# Altium schematic sheet FLTR.SchDoc(FLTR)
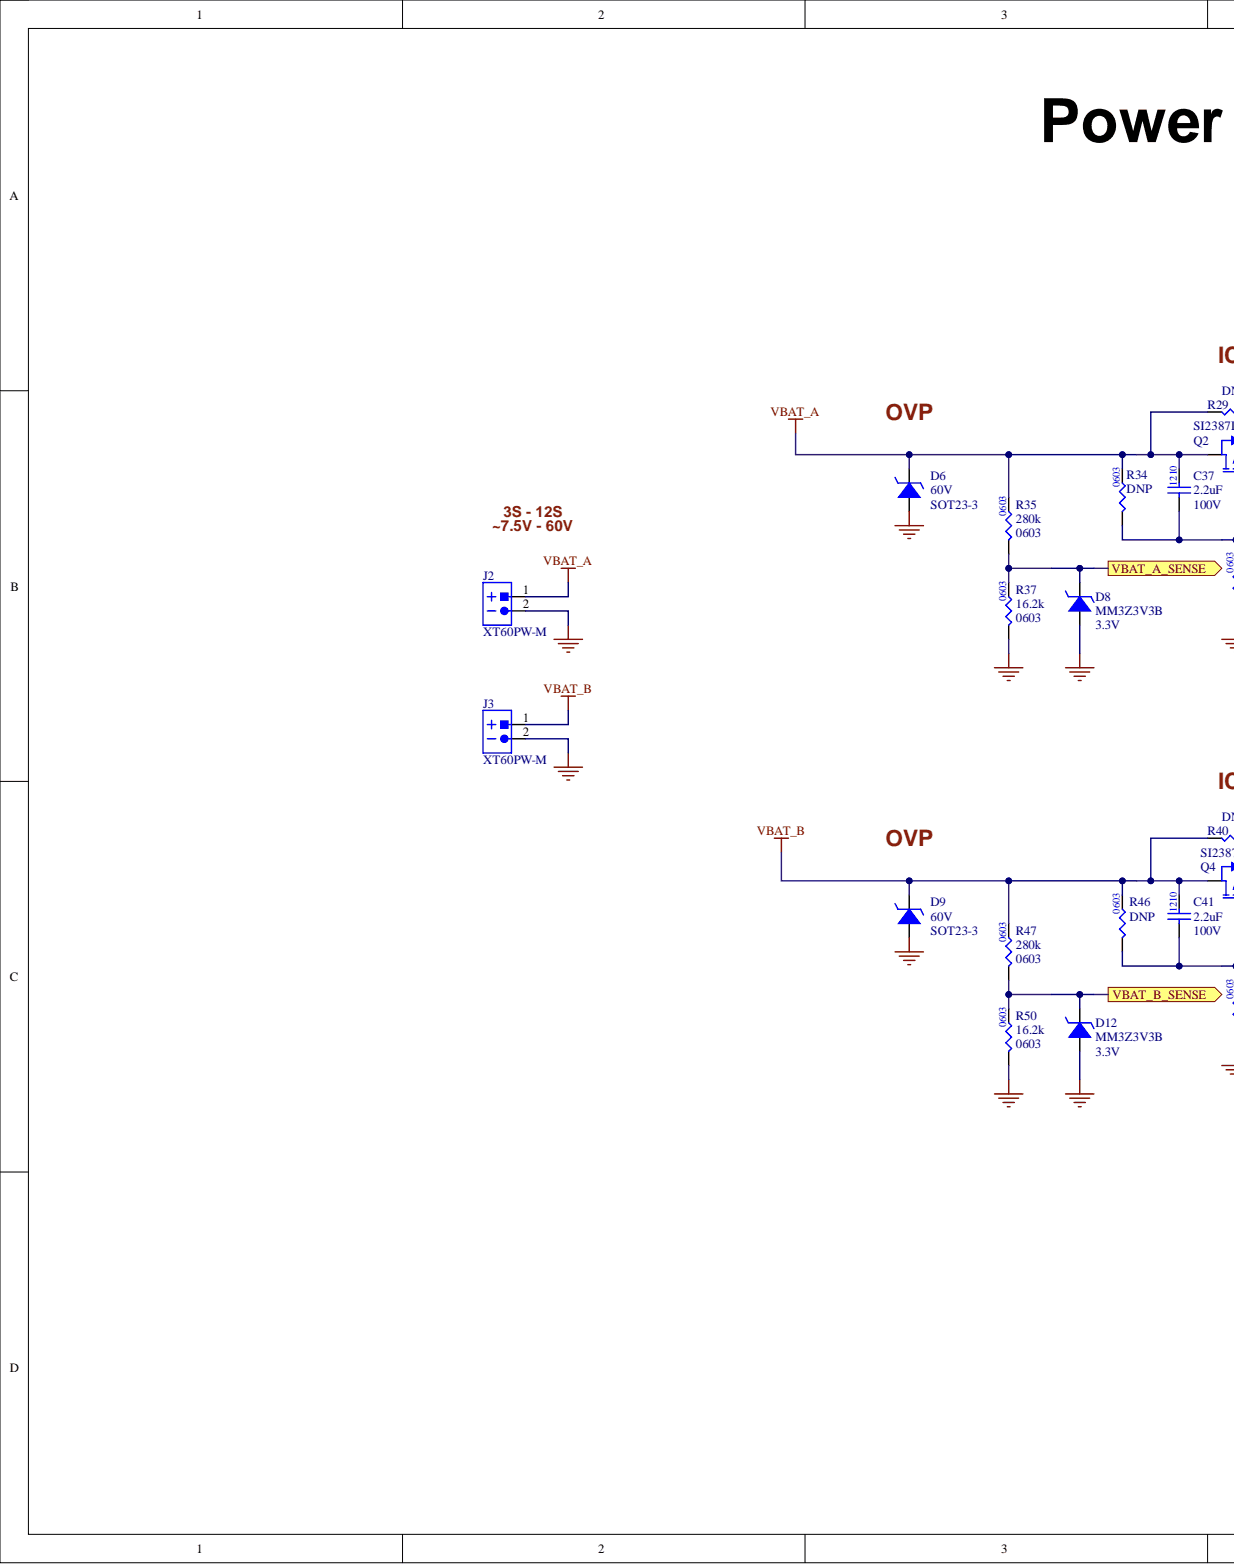
[diagram p0: FLTR.SchDoc, left]
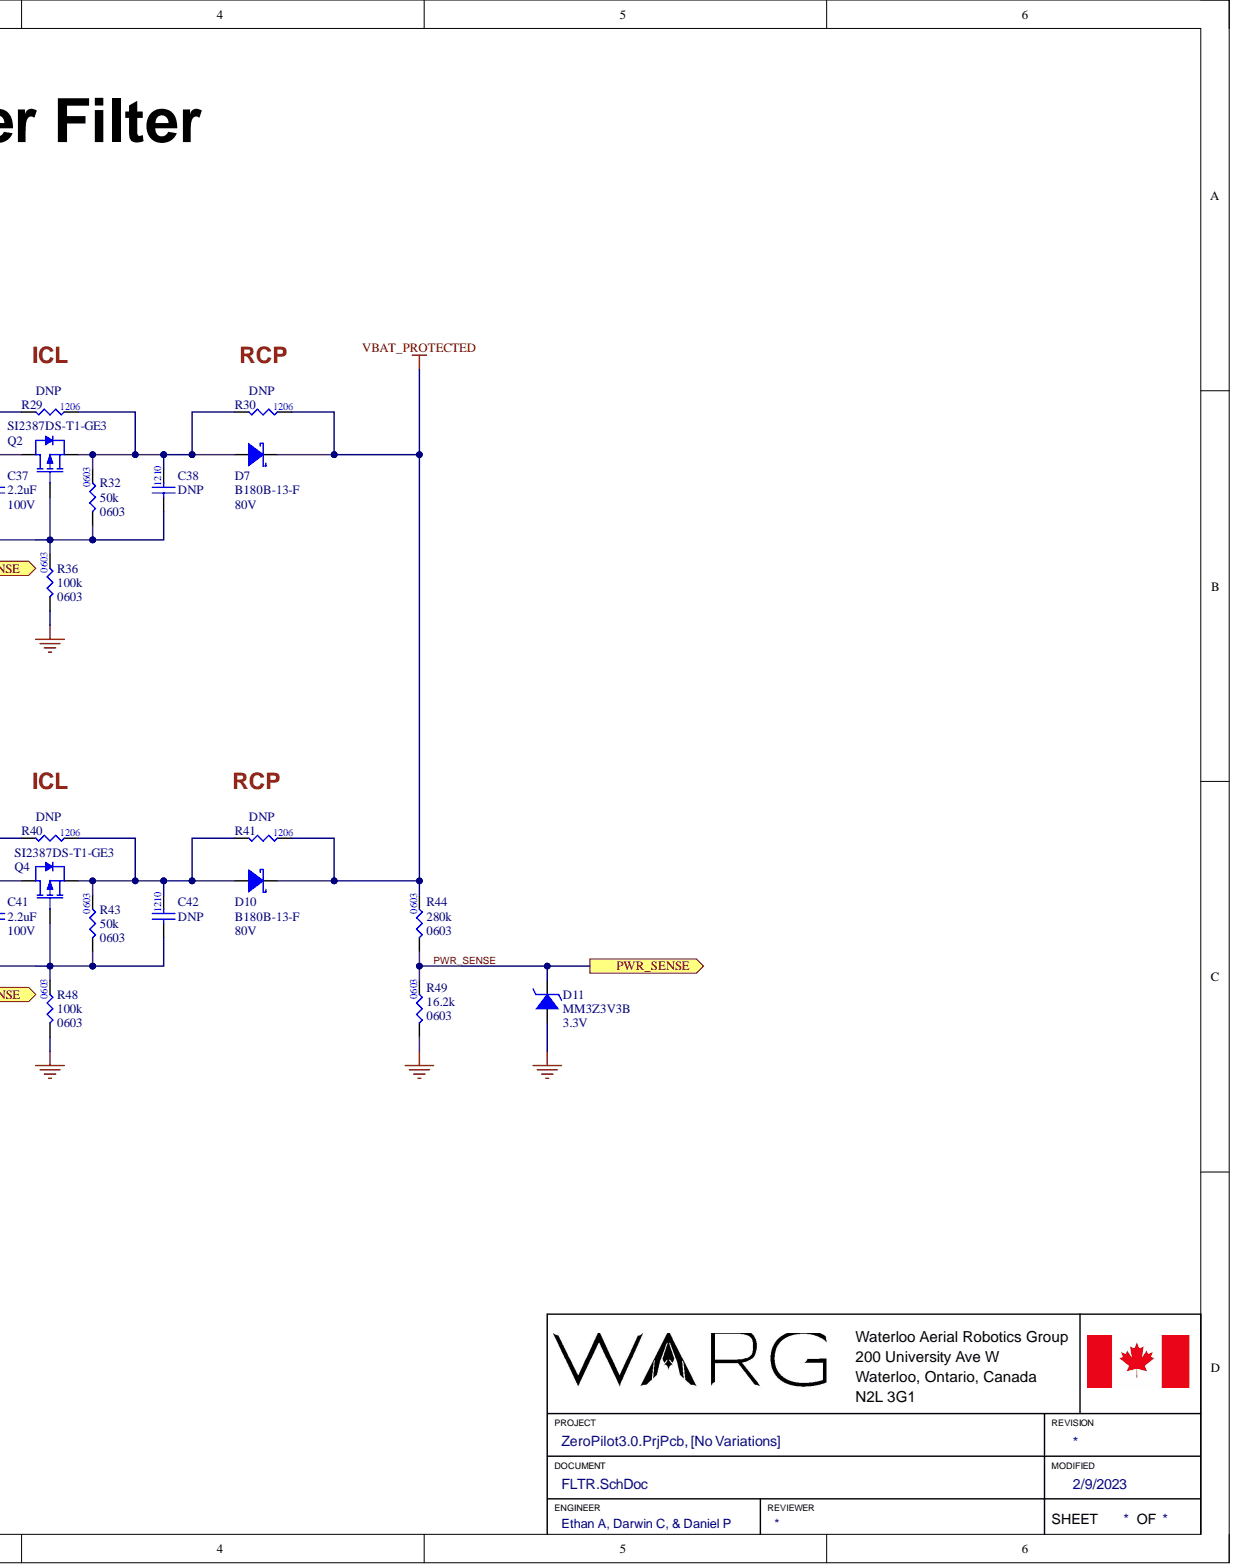
[diagram p1: FLTR.SchDoc, right]

## Parts
C37  value 2.2uF  Case/Package: 1210  Voltage Rating: 100V  (hidden comment: CL32B225KCJSNNE)
C38  value DNP  (hidden comment: .CAP_1210_DNP)
C41  value 2.2uF  Case/Package: 1210  Voltage Rating: 100V  (hidden comment: CL32B225KCJSNNE)
C42  value DNP  (hidden comment: .CAP_1210_DNP)
D6  Case/Package: SOT23-3  Zener Voltage: 60V  (hidden comment: CMPZ5264B TR PBFREE)
D7  B180B-13-F  Max Reverse Voltage (DC): 80V
D8  MM3Z3V3B  Working Voltage: 3.3V
D9  Case/Package: SOT23-3  Zener Voltage: 60V  (hidden comment: CMPZ5264B TR PBFREE)
D10  B180B-13-F  Max Reverse Voltage (DC): 80V
D11  MM3Z3V3B  Working Voltage: 3.3V
D12  MM3Z3V3B  Working Voltage: 3.3V
J2  XT60PW-M
J3  XT60PW-M
Q2  SI2387DS-T1-GE3
Q4  SI2387DS-T1-GE3
R29  value DNP  (hidden comment: .RES_1206_DNP)
R30  value DNP  (hidden comment: .RES_1206_DNP)
R32  value 50k  Case/Package: 0603  (hidden comment: RT0603BRD0750KL)
R34  value DNP  (hidden comment: .RES_0603_DNP)
R35  Case/Package: 0603  Resistance: 280k  (hidden comment: RC0603FR-07280KL)
R36  value 100k  Case/Package: 0603  (hidden comment: RC0603FR-07100KL)
R37  value 16.2k  Case/Package: 0603  (hidden comment: RC0603FR-0716K2L)
R40  value DNP  (hidden comment: .RES_1206_DNP)
R41  value DNP  (hidden comment: .RES_1206_DNP)
R43  value 50k  Case/Package: 0603  (hidden comment: RT0603BRD0750KL)
R44  Case/Package: 0603  Resistance: 280k  (hidden comment: RC0603FR-07280KL)
R46  value DNP  (hidden comment: .RES_0603_DNP)
R47  Case/Package: 0603  Resistance: 280k  (hidden comment: RC0603FR-07280KL)
R48  value 100k  Case/Package: 0603  (hidden comment: RC0603FR-07100KL)
R49  value 16.2k  Case/Package: 0603  (hidden comment: RC0603FR-0716K2L)
R50  value 16.2k  Case/Package: 0603  (hidden comment: RC0603FR-0716K2L)

## Ports
PWR_SENSE, VBAT_A_SENSE, VBAT_B_SENSE

## Nets
GND: D6.1 D8.1 D9.1 D11.1 D12.1 J2.2 J3.2 R36.1 R37.1 R48.1 R49.1 R50.1
VBAT_A: C37.2 D6.2 J2.1 Q2.3 R29.1 R34.2 R35.2
VBAT_B: C41.2 D9.2 J3.1 Q4.3 R40.1 R46.2 R47.2
VBAT_PROTECTED: D7.2 D10.2 R30.2 R41.2 R44.2
PWR_SENSE: D11.2 R44.1 R49.2 ; port PWR_SENSE
VBAT_A_SENSE: D8.2 R35.1 R37.2 ; port VBAT_A_SENSE
VBAT_B_SENSE: D12.2 R47.1 R50.2 ; port VBAT_B_SENSE
NetC37_1: C37.1 C38.1 Q2.1 R32.1 R34.1 R36.2
NetC38_2: C38.2 D7.1 Q2.2 R29.2 R30.1 R32.2
NetC41_1: C41.1 C42.1 Q4.1 R43.1 R46.1 R48.2
NetC42_2: C42.2 D10.1 Q4.2 R40.2 R41.1 R43.2

## Library data of the parts
C37 C41 = CMP-001-00013-5: CAP CER 2.2uF 100V X7R 1210; Component Kind: Standard; Temperature Coefficient: X7R; Library Name: WARG
C38 C42 = CMP-001-00024-2: DNP 1210 Capacitor; Component Kind: Standard; Library Name: WARG
D6 D9 = CMP-004-00009-3: ZENER 60V; Component Kind: Standard; Library Name: WARG
D7 D10 = CMP-004-00010-4: DIODE SCHOTTKY 80V 1A; Forward Voltage: 790mV; Max Forward Surge Current (Ifsm): 30A; Average Rectified Current: 1A; Component Kind: Standard; Library Name: WARG
D8 D11 D12 = CMP-004-00006-4: ZENER 3.3V; Forward Voltage: 1V; Max Power Dissipation: 200mW; Max Reverse Current: 4.5uA; Tolerance: 2%; Component Kind: Standard; Power Dissipation: 200mW; Zener Voltage: 3.3V; Library Name: WARG
J2 J3 = CMP-00007-11: Board Mount XT60PW-M 2 Pin Male Connector; Manufacturer: TME; Component Kind: Standard; Library Name: WARG
Q2 Q4 = CMP-013-00005-1: MOSFET P-CH 80V 3A 242mOhm; Gate Threshold: -2.5V; Component Kind: Standard; Continuous Current: 3A; Drain-to-Source Voltage: 80V; Library Name: WARG
R29 R30 R40 R41 = CMP-009-00021-1: DNP 1206 Resistor; Component Kind: Standard; Library Name: WARG
R32 R43 = CMP-009-00015-3: RES 50k OHM 1% 1/10W 0603; Component Kind: Standard; Power Rating: 1/10W; Library Name: WARG
R34 R46 = CMP-009-00018-3: DNP 0603 Resistor; Component Kind: Standard; Library Name: WARG
R35 R44 R47 = CMP-009-00007-4: RES 280k OHM 1% 1/10W 0603; Voltage Rating: 50V; Component Kind: Standard; Power: 1/10W; Library Name: WARG
R36 R48 = CMP-009-00014-3: RES 100K OHM 1% 1/10W 0603; Voltage Rating: 50V; Component Kind: Standard; Power Rating: 1/10W; Library Name: WARG
R37 R49 R50 = CMP-009-00009-3: RES 16.2k OHM 1% 1/10W 0603; Voltage Rating (DC): 50V; Component Kind: Standard; Power: 1/10W; Library Name: WARG
